# Altium schematic sheet Watch.SchDoc
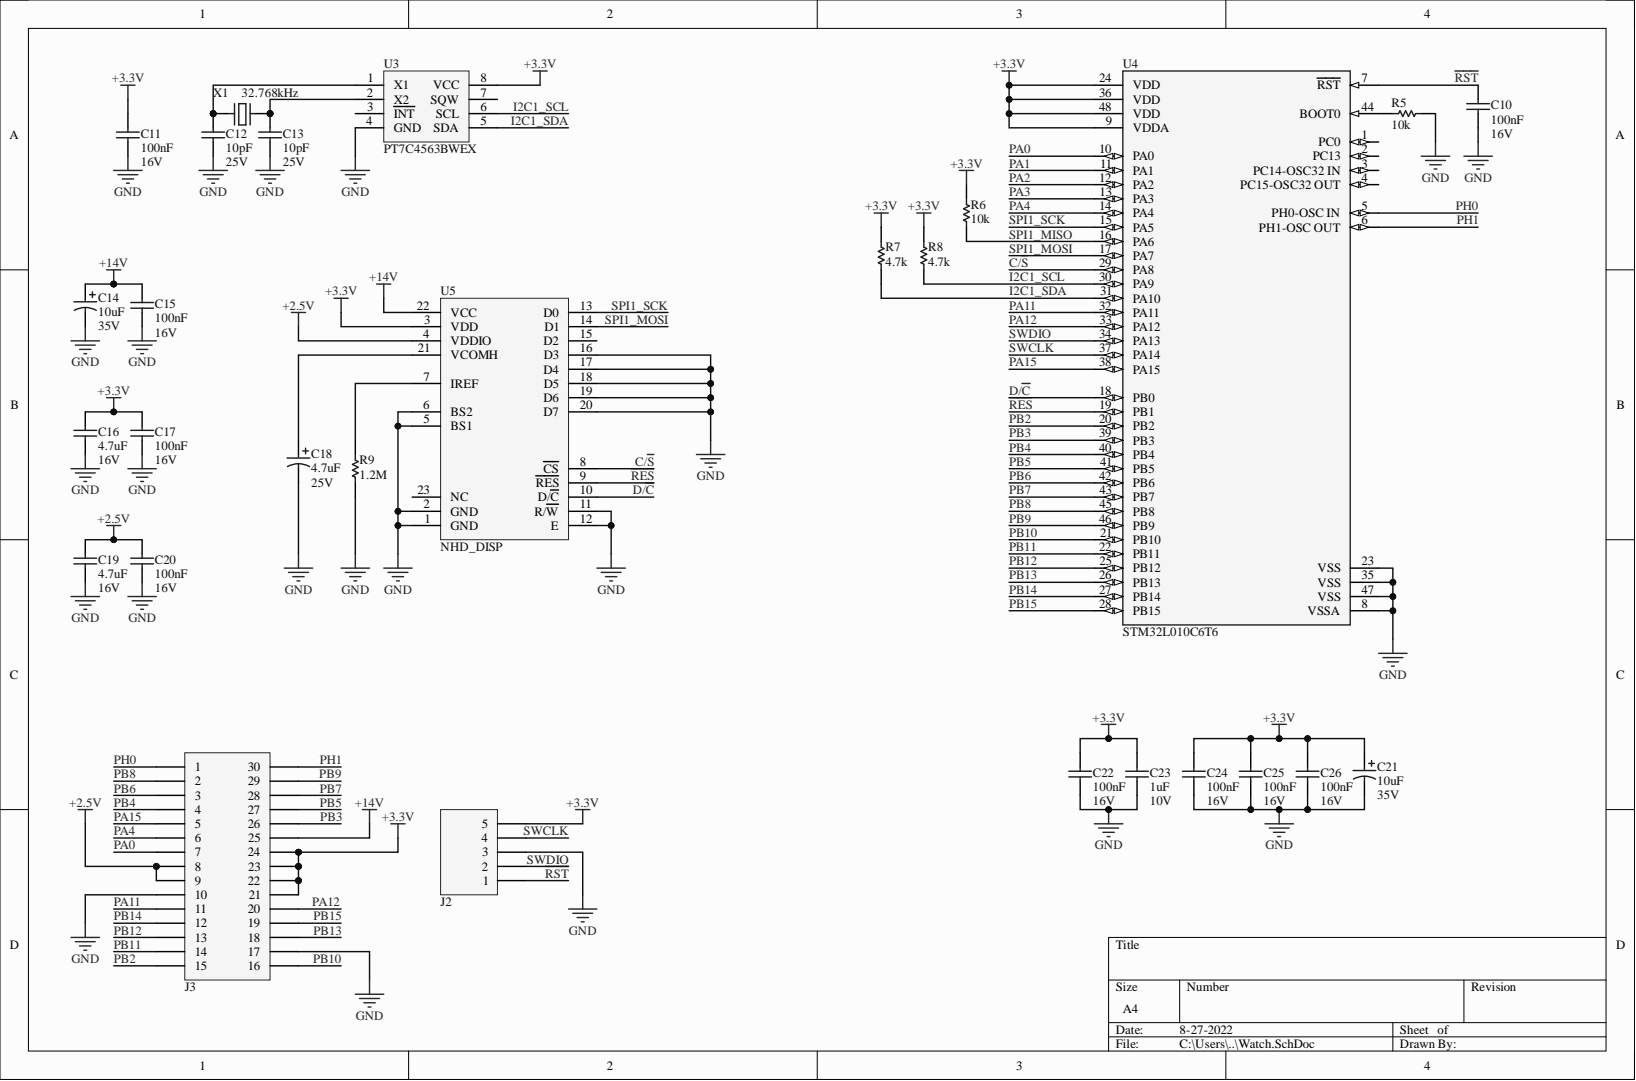
[diagram p0: Watch.SchDoc]

## Parts
C10  value 100nF  Voltage: 16V  [0805_CAPACITOR]  (hidden comment: Capacitor)
C11  value 100nF  Voltage: 16V  [0805_CAPACITOR]  (hidden comment: Capacitor)
C12  value 10pF  Voltage: 25V  [0805_CAPACITOR]  (hidden comment: Capacitor)
C13  value 10pF  Voltage: 25V  [0805_CAPACITOR]  (hidden comment: Capacitor)
C14  value 10uF  Voltage: 35V  [2312_MOLDED_CAP]  (hidden comment: Cap_Pol)
C15  value 100nF  Voltage: 16V  [0805_CAPACITOR]  (hidden comment: Capacitor)
C16  value 4.7uF  Voltage: 16V  [0805_CAPACITOR]  (hidden comment: Capacitor)
C17  value 100nF  Voltage: 16V  [0805_CAPACITOR]  (hidden comment: Capacitor)
C18  value 4.7uF  Voltage: 25V  [1206_MOLDED_CAP]  (hidden comment: Cap_Pol)
C19  value 4.7uF  Voltage: 16V  [0805_CAPACITOR]  (hidden comment: Capacitor)
C20  value 100nF  Voltage: 16V  [0805_CAPACITOR]  (hidden comment: Capacitor)
C21  value 10uF  Voltage: 35V  [2312_MOLDED_CAP]  (hidden comment: Cap_Pol)
C22  value 100nF  Voltage: 16V  [0805_CAPACITOR]  (hidden comment: Capacitor)
C23  value 1uF  Voltage: 10V  [0805_CAPACITOR]  (hidden comment: Capacitor)
C24  value 100nF  Voltage: 16V  [0805_CAPACITOR]  (hidden comment: Capacitor)
C25  value 100nF  Voltage: 16V  [0805_CAPACITOR]  (hidden comment: Capacitor)
C26  value 100nF  Voltage: 16V  [0805_CAPACITOR]  (hidden comment: Capacitor)
J2  Header_5  [Header_5]  (value not drawn)
J3  Header_15X2  [Header_15X2]  (value not drawn)
R5  value 10k  [0805_RESISTOR]  (hidden comment: Resistor)
R6  value 10k  [0805_RESISTOR]  (hidden comment: Resistor)
R7  value 4.7k  [0805_RESISTOR]  (hidden comment: Resistor)
R8  value 4.7k  [0805_RESISTOR]  (hidden comment: Resistor)
R9  value 1.2M  [0805_RESISTOR]  (hidden comment: Resistor)
U3  PT7C4563BWEX  [SOIC8]
U4  STM32L010C6T6  [STM32L010C6T6]
U5  NHD_DISP  [NHD_DISP]
X1  value 32.768kHz  [LFXTAL025159REEL]  (hidden comment: Oscillator)

## Nets
+2.5V: C19.2 C20.2 J3.8 J3.9 U5.4(VDDIO)
+3.3V: C11.2 C16.2 C17.2 C21.1 C22.2 C23.1 C24.2 C25.2 C26.2 J2.5 J3.21 J3.22 J3.23 J3.24 R6.2 R7.2 R8.2 U3.8(VCC) U4.9(VDDA) U4.24(VDD) U4.36(VDD) U4.48(VDD) U5.3(VDD)
+14V: C14.1 C15.2 J3.25 U5.22(VCC)
GND: C10.1 C11.1 C12.1 C13.1 C14.2 C15.1 C16.1 C17.1 C18.2 C19.1 C20.1 C21.2 C22.1 C23.2 C24.1 C25.1 C26.1 J2.3 J3.10 J3.17 R5.2 R9.1 U3.4(GND) U4.8(VSSA) U4.23(VSS) U4.35(VSS) U4.47(VSS) U5.1(GND) U5.2(GND) U5.5(BS1) U5.6(BS2) U5.11(R/W) U5.12(E) U5.16(D3) U5.17(D4) U5.18(D5) U5.19(D6) U5.20(D7)
C/~{S}: U4.29(PA8) U5.8(CS)
D/~{C}: U4.18(PB0) U5.10(D/C)
I2C1_SCL: R8.1 U3.6(SCL) U4.30(PA9)
I2C1_SDA: R7.1 U3.5(SDA) U4.31(PA10)
PA0: J3.7 U4.10(PA0)
PA1: U4.11(PA1)
PA2: U4.12(PA2)
PA3: U4.13(PA3)
PA4: J3.6 U4.14(PA4)
PA11: J3.11 U4.32(PA11)
PA12: J3.20 U4.33(PA12)
PA15: J3.5 U4.38(PA15)
PB2: J3.15 U4.20(PB2)
PB3: J3.26 U4.39(PB3)
PB4: J3.4 U4.40(PB4)
PB5: J3.27 U4.41(PB5)
PB6: J3.3 U4.42(PB6)
PB7: J3.28 U4.43(PB7)
PB8: J3.2 U4.45(PB8)
PB9: J3.29 U4.46(PB9)
PB10: J3.16 U4.21(PB10)
PB11: J3.14 U4.22(PB11)
PB12: J3.13 U4.25(PB12)
PB13: J3.18 U4.26(PB13)
PB14: J3.12 U4.27(PB14)
PB15: J3.19 U4.28(PB15)
PH0: J3.1 U4.5(PH0-OSC_IN)
PH1: J3.30 U4.6(PH1-OSC_OUT)
~{RES}: U4.19(PB1) U5.9(RES)
~{RST}: C10.2 J2.1 U4.7(RST)
SPI1_MISO: R6.1 U4.16(PA6)
SPI1_MOSI: U4.17(PA7) U5.14(D1)
SPI1_SCK: U4.15(PA5) U5.13(D0)
SWCLK: J2.4 U4.37(PA14)
SWDIO: J2.2 U4.34(PA13)
NetC12_2: C12.2 U3.1(X1) X1.2
NetC13_2: C13.2 U3.2(X2) X1.1
NetC18_1: C18.1 U5.21(VCOMH)
NetR5_1: R5.1 U4.44(BOOT0)
NetR9_2: R9.2 U5.7(IREF)

Not connected: U3 3(INT) 7(SQW); U4 1(PC0) 2(PC13) 3(PC14-OSC32_IN) 4(PC15-OSC32_OUT); U5 15(D2) 23(NC)

## Library data of the parts
C10 C11 C15 C17 C20 C22 C24-C26 = C000004: Unpolarized Capacitor; Manufacterer Part Number: C0805C104K4RECAUTO; Database Table Name: C - Capacitors$; Type: Ceramic X7R; Case: 0805; Component Kind: Standard; Datasheet: https://connect.kemet.com:7667/gateway/IntelliData-ComponentDocumentation/1.0/download/datasheet/C0805C104K4RECAUTO; Part Number: C000004; Library Name: Qureshi Database.DbLib
C12 C13 = C000008: Unpolarized Capacitor; Manufacterer Part Number: C0805C100J3GAC7800; Database Table Name: C - Capacitors$; Tolerance: 0.05; Type: Ceramic C0G; Case: 0805; Component Kind: Standard; Datasheet: https://connect.kemet.com:7667/gateway/IntelliData-ComponentDocumentation/1.0/download/datasheet/C0805C100J3GACTU; Part Number: C000008; Library Name: Qureshi Database.DbLib
C14 C21 = C000007: Polarized Capacitor; Manufacterer Part Number: T491C106M025AT7280; Database Table Name: C - Capacitors$; Tolerance: 0.2; Type: Tantalum; Case: 2312; Component Kind: Standard; Datasheet: https://content.kemet.com/datasheets/KEM_T2005_T491.pdf; Part Number: C000007; Library Name: Qureshi Database.DbLib
C16 C19 = C000005: Unpolarized Capacitor; Manufacterer Part Number: C0805C475K4RAC7210; Database Table Name: C - Capacitors$; Tolerance: 0.1; Type: Ceramic X7R; Case: 0805; Component Kind: Standard; Datasheet: https://connect.kemet.com:7667/gateway/IntelliData-ComponentDocumentation/1.0/download/datasheet/C0805C475K4RAC7210; Part Number: C000005; Library Name: Qureshi Database.DbLib
C18 = C000006: Polarized Capacitor; Manufacterer Part Number: T491A475K025AT; Database Table Name: C - Capacitors$; Tolerance: 0.1; Type: Tantalum; Case: 1206; Component Kind: Standard; Datasheet: https://content.kemet.com/datasheets/KEM_T2005_T491.pdf; Part Number: C000006; Library Name: Qureshi Database.DbLib
C23 = C000003: Unpolarized Capacitor; Manufacterer Part Number: C0805C105M8RAC7800; Database Table Name: C - Capacitors$; Type: Ceramic X7R; Case: 0805; Component Kind: Standard; Datasheet: https://connect.kemet.com:7667/gateway/IntelliData-ComponentDocumentation/1.0/download/datasheet/C0805C105M8RACTU; Part Number: C000003; Library Name: Qureshi Database.DbLib
J2 = J000003: Header 5; Database Table Name: J - Jacks$; Component Kind: Standard; Part Number: J000003; Type: 5 POS Rect Header, right angle; Library Name: Qureshi Database.DbLib
J3 = J000004: 15X2 Header; Database Table Name: J - Jacks$; Component Kind: Standard; Part Number: J000004; Type: 15X2 POS Rect Header; Library Name: Qureshi Database.DbLib
R5 R6 = R000005: Manufacterer Part Number: CRCW080510K0FKEAC; Database Table Name: R - Resistors$; Inv Qty: 0; Power Rating: 125mW; Tolerance: 0.01; Case: 0805; Component Kind: Standard; Datasheet: https://www.mouser.ca/datasheet/2/427/crcwce3-1762584.pdf; Part Number: R000005; Library Name: Qureshi Database.DbLib
R7 R8 = R000007: Manufacterer Part Number: CRCW08054K70FKTA; Database Table Name: R - Resistors$; Inv Qty: 0; Power Rating: 125mW; Tolerance: 0.01; Case: 0805; Component Kind: Standard; Datasheet: https://www.vishay.com/docs/20035/dcrcwe3.pdf; Part Number: R000007; Library Name: Qureshi Database.DbLib
R9 = R000006: Manufacterer Part Number: CRCW08051M20JNEA; Database Table Name: R - Resistors$; Inv Qty: 0; Power Rating: 125mW; Tolerance: 0.05; Case: 0805; Component Kind: Standard; Datasheet: https://www.vishay.com/docs/20035/dcrcwe3.pdf; Part Number: R000006; Library Name: Qureshi Database.DbLib
U3 = U000006: Database Table Name: U - ICs$; Inv Qty: 0; Component Kind: Standard; Datasheet Link: https://www.diodes.com/assets/Datasheets/PT7C4563B.pdf; Manufacterer Part Number: PT7C4563BWEX; Part Number: U000006; Library Name: Qureshi Database.DbLib
U4 = U000001: Database Table Name: U - ICs$; Inv Qty: 15; Component Kind: Standard; Datasheet Link: https://www.st.com/resource/en/datasheet/stm32l010c6.pdf; Description: STM32L010C6T6; Manufacterer Part Number: STM32L010C6T6; Part Number: U000001; Library Name: Qureshi Database.DbLib
U5 = U000004: 0.95" OLED Display SPI/Parallel; Manufacterer Part Number: NHD-0.95-9664G; Database Table Name: U - ICs$; Inv Qty: 0; Component Kind: Standard; Datasheet Link: https://www.digikey.ca/en/products/detail/newhaven-display-intl/NHD-0-95-9664G/9842781; Part Number: U000004; Library Name: Qureshi Database.DbLib
X1 = X000001: Database Table Name: X - Oscillator$; Component Kind: Standard; Datasheet: https://www.iqdfrequencyproducts.com/products/pn/LFXTAL025159Reel.pdf; Manufacterer Part Number: LFXTAL025159REEL; Part Number: X000001; Type: SMD, can; Library Name: Qureshi Database.DbLib
